# Altium schematic sheet Sheet1.SchDoc(Sheet1)
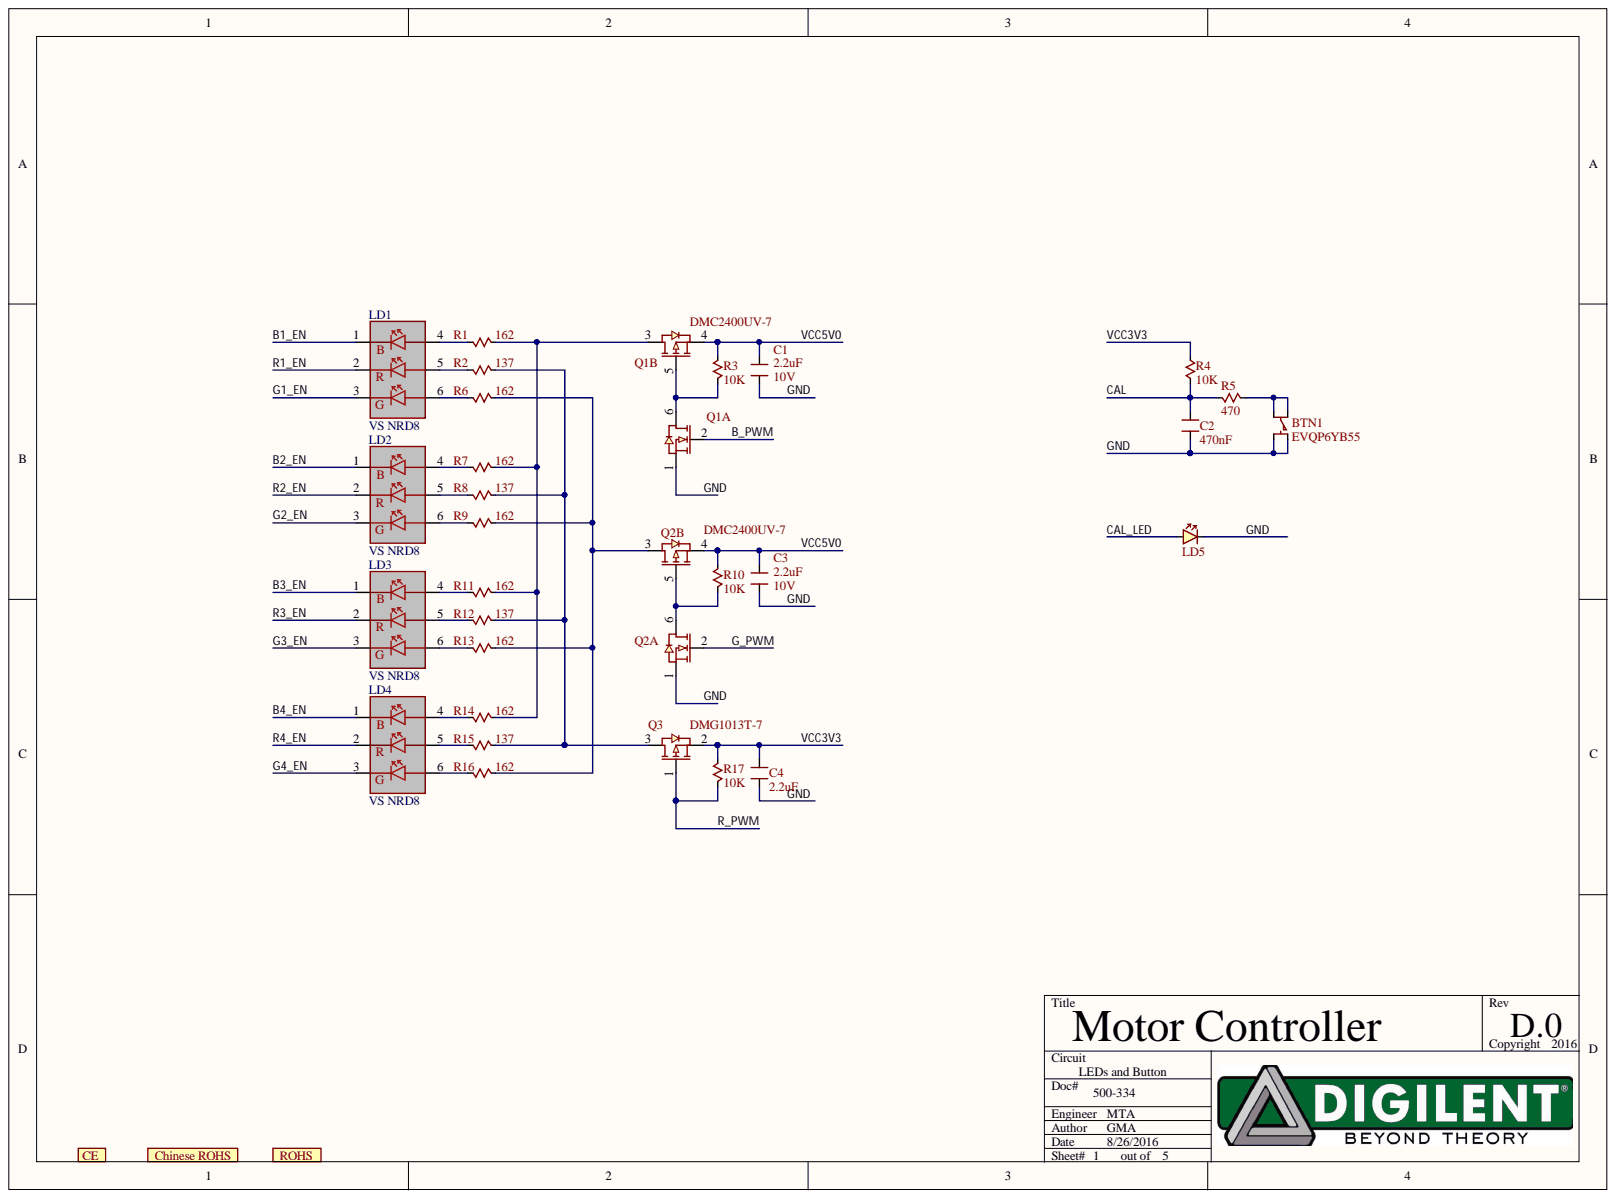
[diagram p0: Sheet1.SchDoc]

## Parts
BTN1  EVQP6YB55  [EVQP6YB55]
C1  10V  value 2.2uF  [CAP0603]
C2  value 470nF  [CAP0402]  (hidden comment: CAPACITOR)
C3  10V  value 2.2uF  [CAP0603]
C4  value 2.2uF  [CAP0603]  (hidden comment: CAPACITOR)
LD1  VS NRD8  [NRD8]
LD2  VS NRD8  [NRD8]
LD3  VS NRD8  [NRD8]
LD4  VS NRD8  [NRD8]
LD5  RED Highbright  [D0603]  (value not drawn)
LG1  Logo_CE  [Logo_CE-0.5]  (value not drawn)  (no BOM)
LG2  Logo_Chinese ROHS  [LOGO_CHINESE-ROHS-2]  (value not drawn)  (no BOM)
LG3  Logo_ROHS  [LOGO_ROHS-2]  (value not drawn)  (no BOM)
Q1  DMC2400UV-7  [SOT-563]  (parts A,B)
Q2  DMC2400UV-7  [SOT-563]  (parts A,B)
Q3  DMG1013T-7  [SOT523]
R1  value 162  [RES0402]  (hidden comment: RESISTOR)
R2  value 137  [RES0402]  (hidden comment: RESISTOR)
R3  value 10K  [RES0402]  (hidden comment: RESISTOR)
R4  value 10K  [RES0402]  (hidden comment: RESISTOR)
R5  value 470  [RES0402]  (hidden comment: RESISTOR)
R6  value 162  [RES0402]  (hidden comment: RESISTOR)
R7  value 162  [RES0402]  (hidden comment: RESISTOR)
R8  value 137  [RES0402]  (hidden comment: RESISTOR)
R9  value 162  [RES0402]  (hidden comment: RESISTOR)
R10  value 10K  [RES0402]  (hidden comment: RESISTOR)
R11  value 162  [RES0402]  (hidden comment: RESISTOR)
R12  value 137  [RES0402]  (hidden comment: RESISTOR)
R13  value 162  [RES0402]  (hidden comment: RESISTOR)
R14  value 162  [RES0402]  (hidden comment: RESISTOR)
R15  value 137  [RES0402]  (hidden comment: RESISTOR)
R16  value 162  [RES0402]  (hidden comment: RESISTOR)
R17  value 10K  [RES0402]  (hidden comment: RESISTOR)

## Nets
B1_EN: LD1.1
B2_EN: LD2.1
B3_EN: LD3.1
B4_EN: LD4.1
B_PWM: Q1.2
CAL: C2.1 R4.2 R5.1
CAL_LED: LD5.A
G1_EN: LD1.3
G2_EN: LD2.3
G3_EN: LD3.3
G4_EN: LD4.3
G_PWM: Q2.2
GND: BTN1.3 BTN1.4 C1.2 C2.2 C3.2 C4.2 LD5.K Q1.1 Q2.1
R1_EN: LD1.2
R2_EN: LD2.2
R3_EN: LD3.2
R4_EN: LD4.2
R_PWM: Q3.1 R17.2
VCC3V3: C4.1 Q3.2 R4.1 R17.1
VCC5V0: C1.1 C3.1 Q1.4 Q2.4 R3.1 R10.1
NetBTN1_1: BTN1.1 BTN1.2 R5.2
NetLD1_4: LD1.4 R1.2
NetLD1_5: LD1.5 R2.2
NetLD1_6: LD1.6 R6.2
NetLD2_4: LD2.4 R7.2
NetLD2_5: LD2.5 R8.2
NetLD2_6: LD2.6 R9.2
NetLD3_4: LD3.4 R11.2
NetLD3_5: LD3.5 R12.2
NetLD3_6: LD3.6 R13.2
NetLD4_4: LD4.4 R14.2
NetLD4_5: LD4.5 R15.2
NetLD4_6: LD4.6 R16.2
NetQ1_3: Q1.3 R1.1 R7.1 R11.1 R14.1
NetQ1_5: Q1.5 Q1.6 R3.2
NetQ2_3: Q2.3 R6.1 R9.1 R13.1 R16.1
NetQ2_5: Q2.5 Q2.6 R10.2
NetQ3_3: Q3.3 R2.1 R8.1 R12.1 R15.1

## Library data of the parts
BTN1 = EVQP6YB55: 4 Pin Button, SMT; Manufacturer: Panasonic; LoadStatus: Load; Component Kind: Standard; Library Name: DigilentUN.IntLib
C1-C4 = CAPACITOR: LoadStatus: Load; Component Kind: Standard; Description: Capacitor; Library Name: DigilentUN.IntLib
LD1-LD4 = VS NRD8: Full Color SMT LED, 1.6x1.6mm; Manufacturer: Victory Electronics; Component Kind: Standard; Library Name: DigilentUN.IntLib
LD5 = LED: Generic LED; LoadStatus: Load; Component Kind: Standard; Value: RED; Library Name: DigilentUN.IntLib
LG1 = Logo_CE: CE logo; LoadStatus: NA; Manufacturer: NA; Library Name: DigilentUN.IntLib
LG2 = Logo_Chinese ROHS: Chinese ROHS Symbol; LoadStatus: NA; Manufacturer: NA; Library Name: DigilentUN.IntLib
LG3 = Logo_ROHS: ROHS (WEEE) Symbol; LoadStatus: NA; Manufacturer: NA; Library Name: DigilentUN.IntLib
Q1 Q2 = DMC2400UV: N and P-Channel Mosfet 1A, 8V to 29V Complementary; Manufacturer: Diodes Inc.; LoadStatus: Load; Component Kind: Standard; Library Name: DigilentUN.IntLib
Q3 = DMG1013T: P-Channel Enhancement Mode MOSFET, -20V, -460mA; Manufacturer: Diodes Inc.; LoadStatus: Load; Component Kind: Standard; Library Name: DigilentUN.IntLib
R1-R17 = RESISTOR: LoadStatus: Load; Component Kind: Standard; Description: Resistor; Library Name: DigilentUN.IntLib
